# Altium schematic sheet Sheet2.SchDoc
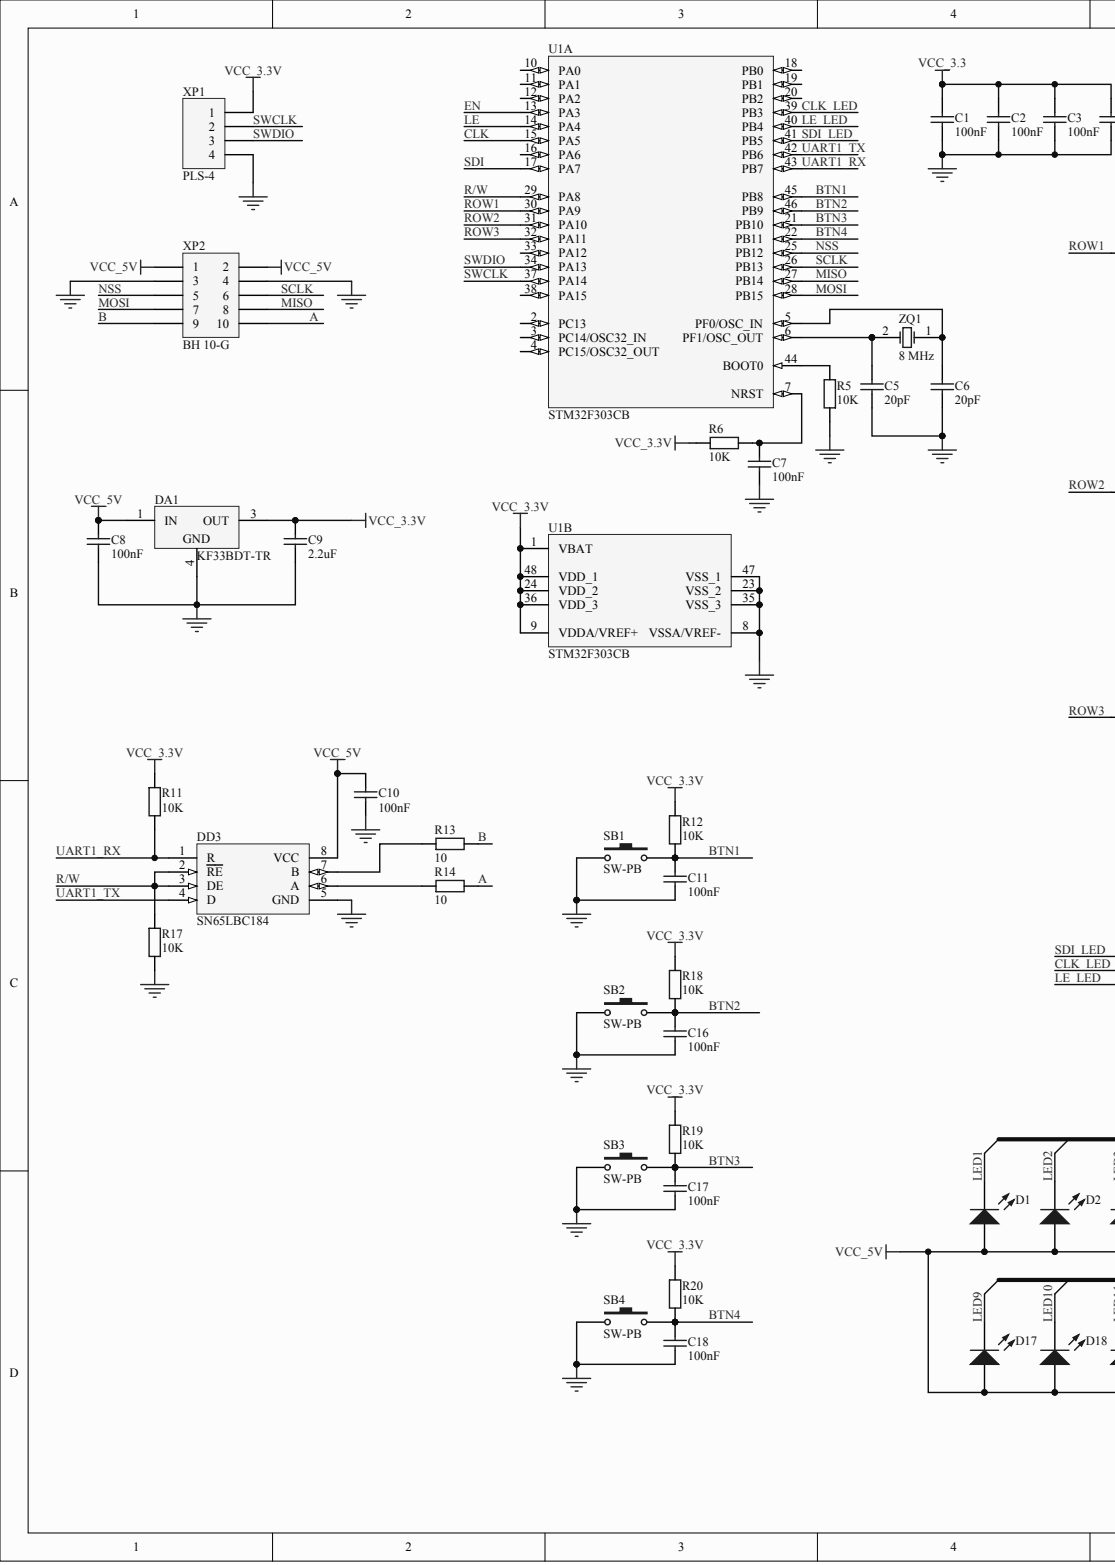
[diagram p0: Sheet2.SchDoc, left]
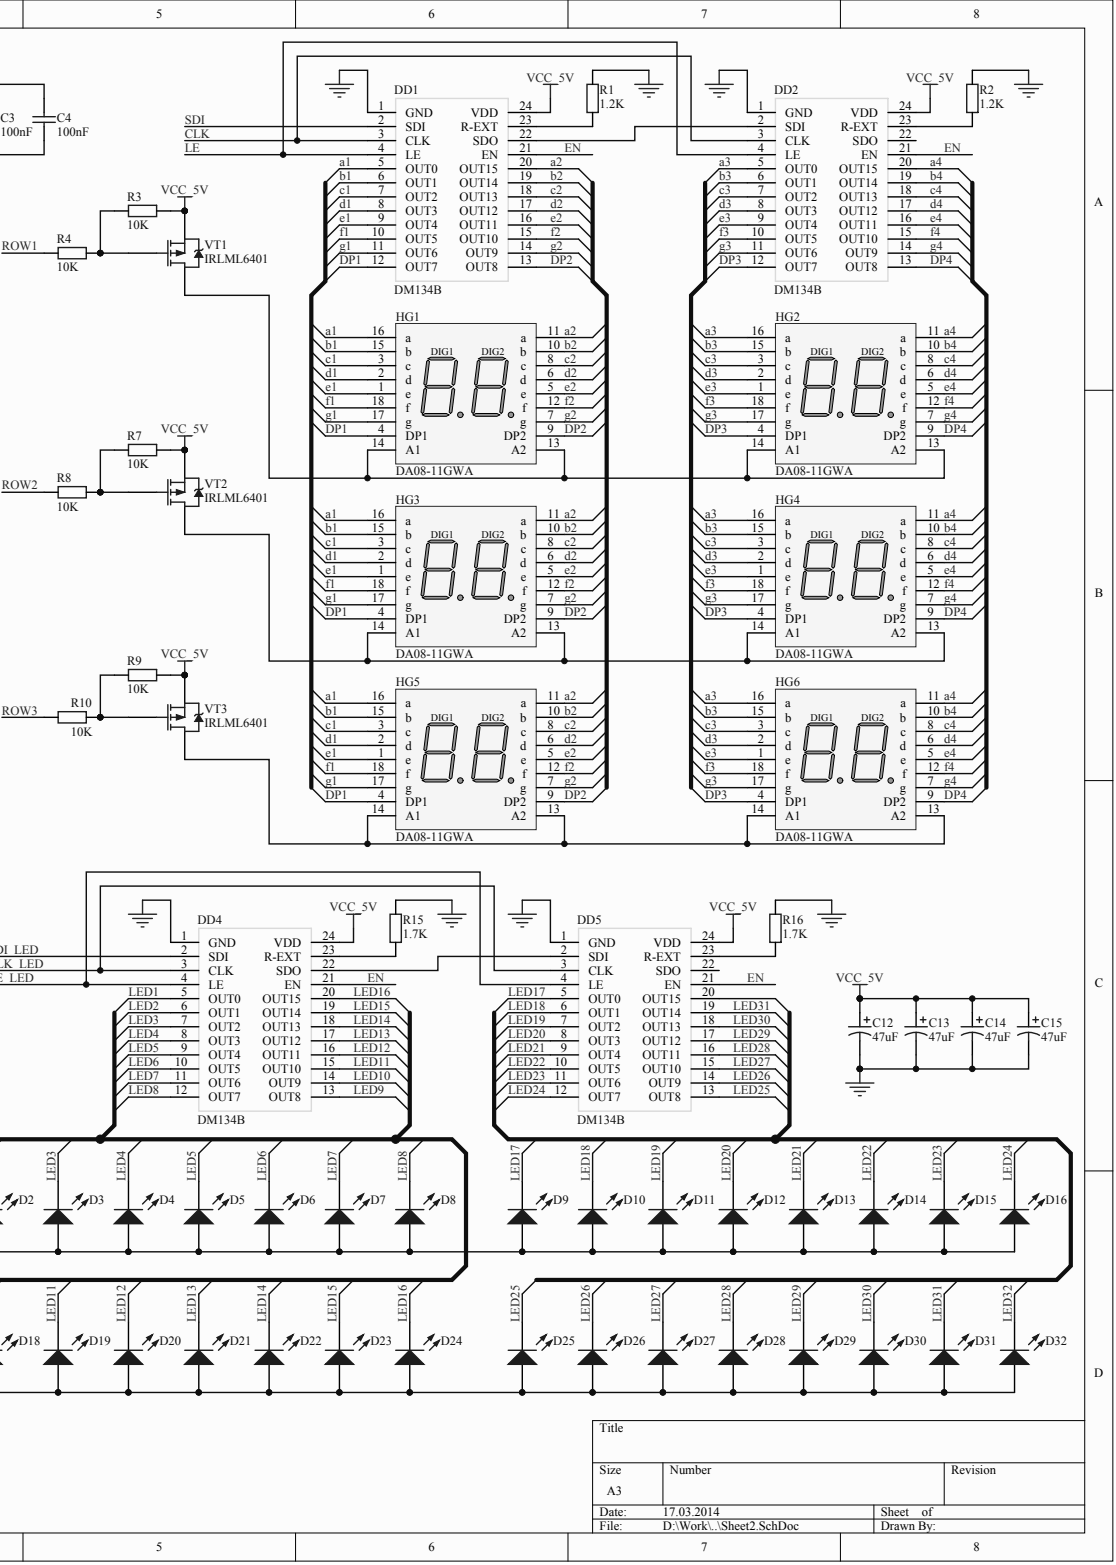
[diagram p1: Sheet2.SchDoc, right]

## Parts
C1  100nF
C2  100nF
C3  100nF
C4  100nF
C5  20pF
C6  20pF
C7  100nF
C8  100nF
C9  2.2uF
C10  100nF
C11  100nF
C12  47uF
C13  47uF
C14  47uF
C15  47uF
C16  100nF
C17  100nF
C18  100nF
D1  LED0  (value not drawn)
D2  LED0  (value not drawn)
D3  LED0  (value not drawn)
D4  LED0  (value not drawn)
D5  LED0  (value not drawn)
D6  LED0  (value not drawn)
D7  LED0  (value not drawn)
D8  LED0  (value not drawn)
D9  LED0  (value not drawn)
D10  LED0  (value not drawn)
D11  LED0  (value not drawn)
D12  LED0  (value not drawn)
D13  LED0  (value not drawn)
D14  LED0  (value not drawn)
D15  LED0  (value not drawn)
D16  LED0  (value not drawn)
D17  LED0  (value not drawn)
D18  LED0  (value not drawn)
D19  LED0  (value not drawn)
D20  LED0  (value not drawn)
D21  LED0  (value not drawn)
D22  LED0  (value not drawn)
D23  LED0  (value not drawn)
D24  LED0  (value not drawn)
D25  LED0  (value not drawn)
D26  LED0  (value not drawn)
D27  LED0  (value not drawn)
D28  LED0  (value not drawn)
D29  LED0  (value not drawn)
D30  LED0  (value not drawn)
D31  LED0  (value not drawn)
D32  LED0  (value not drawn)
DA1  KF33BDT-TR
DD1  DM134B
DD2  DM134B
DD3  SN65LBC184
DD4  DM134B
DD5  DM134B
HG1  DA08-11GWA
HG2  DA08-11GWA
HG3  DA08-11GWA
HG4  DA08-11GWA
HG5  DA08-11GWA
HG6  DA08-11GWA
R1  1.2K
R2  1.2K
R3  10K
R4  10K
R5  10K
R6  10K
R7  10K
R8  10K
R9  10K
R10  10K
R11  10K
R12  10K
R13  10
R14  10
R15  1.7K
R16  1.7K
R17  10K
R18  10K
R19  10K
R20  10K
SB1  SW-PB
SB2  SW-PB
SB3  SW-PB
SB4  SW-PB
U1  STM32F303CB  (parts A,B)
VT1  IRLML6401
VT2  IRLML6401
VT3  IRLML6401
XP1  PLS-4
XP2  BH 10-G
ZQ1  8 MHz

## Nets
VCC_3.3: C1.2 C2.2 C3.2 C4.2
VCC_3.3V: C9.2 DA1.3 R6.2 R11.2 R12.2 R18.2 R19.2 R20.2 U1.1(VBAT) U1.9(VDDA/VREF+) U1.24(VDD_2) U1.36(VDD_3) U1.48(VDD_1) XP1.1
VCC_5V: C8.2 C10.1 C12.1 C13.1 C14.1 C15.1 D1.1 D2.1 D3.1 D4.1 D5.1 D6.1 D7.1 D8.1 D9.1 D10.1 D11.1 D12.1 D13.1 D14.1 D15.1 D16.1 D17.1 D18.1 D19.1 D20.1 D21.1 D22.1 D23.1 D24.1 D25.1 D26.1 D27.1 D28.1 D29.1 D30.1 D31.1 D32.1 DA1.1 DD1.24(VDD) DD2.24(VDD) DD3.8(VCC) DD4.24(VDD) DD5.24(VDD) R3.2 R7.2 R9.2 VT1.2 VT2.2 VT3.2 XP2.1 XP2.2
: C1.1 C2.1 C3.1 C4.1 C5.1 C6.1 C7.1 C8.1 C9.1 C10.2 C11.1 C12.2 C13.2 C14.2 C15.2 C16.1 C17.1 C18.1 DA1.4 DD1.1(GND) DD2.1(GND) DD3.5(GND) DD4.1(GND) DD5.1(GND) R1.1 R2.1 R5.2 R15.1 R16.1 R17.1 SB1.2 SB2.2 SB3.2 SB4.2 U1.8(VSSA/VREF-) U1.23(VSS_2) U1.35(VSS_3) U1.47(VSS_1) XP1.4 XP2.3 XP2.4
A: R14.1 XP2.10
A1: DD1.5(OUT0) HG1.16(a) HG3.16(a) HG5.16(a)
A2: DD1.20(OUT15) HG1.11(a) HG3.11(a) HG5.11(a)
A3: DD2.5(OUT0) HG2.16(a) HG4.16(a) HG6.16(a)
A4: DD2.20(OUT15) HG2.11(a) HG4.11(a) HG6.11(a)
B: R13.1 XP2.9
B1: DD1.6(OUT1) HG1.15(b) HG3.15(b) HG5.15(b)
B2: DD1.19(OUT14) HG1.10(b) HG3.10(b) HG5.10(b)
B3: DD2.6(OUT1) HG2.15(b) HG4.15(b) HG6.15(b)
B4: DD2.19(OUT14) HG2.10(b) HG4.10(b) HG6.10(b)
BTN1: C11.2 R12.1 SB1.1 U1.45(PB8)
BTN2: C16.2 R18.1 SB2.1 U1.46(PB9)
BTN3: C17.2 R19.1 SB3.1 U1.21(PB10)
BTN4: C18.2 R20.1 SB4.1 U1.22(PB11)
C1: DD1.7(OUT2) HG1.3(c) HG3.3(c) HG5.3(c)
C2: DD1.18(OUT13) HG1.8(c) HG3.8(c) HG5.8(c)
C3: DD2.7(OUT2) HG2.3(c) HG4.3(c) HG6.3(c)
C4: DD2.18(OUT13) HG2.8(c) HG4.8(c) HG6.8(c)
CLK: DD1.3(CLK) DD2.3(CLK) U1.15(PA5)
CLK_LED: DD4.3(CLK) DD5.3(CLK) U1.39(PB3)
D1: DD1.8(OUT3) HG1.2(d) HG3.2(d) HG5.2(d)
D2: DD1.17(OUT12) HG1.6(d) HG3.6(d) HG5.6(d)
D3: DD2.8(OUT3) HG2.2(d) HG4.2(d) HG6.2(d)
D4: DD2.17(OUT12) HG2.6(d) HG4.6(d) HG6.6(d)
DP1: DD1.12(OUT7) HG1.4(DP1) HG3.4(DP1) HG5.4(DP1)
DP2: DD1.13(OUT8) HG1.9(DP2) HG3.9(DP2) HG5.9(DP2)
DP3: DD2.12(OUT7) HG2.4(DP1) HG4.4(DP1) HG6.4(DP1)
DP4: DD2.13(OUT8) HG2.9(DP2) HG4.9(DP2) HG6.9(DP2)
E1: DD1.9(OUT4) HG1.1(e) HG3.1(e) HG5.1(e)
E2: DD1.16(OUT11) HG1.5(e) HG3.5(e) HG5.5(e)
E3: DD2.9(OUT4) HG2.1(e) HG4.1(e) HG6.1(e)
E4: DD2.16(OUT11) HG2.5(e) HG4.5(e) HG6.5(e)
EN: DD1.21(EN) DD2.21(EN) DD4.21(EN) DD5.21(EN) U1.13(PA3)
F1: DD1.10(OUT5) HG1.18(f) HG3.18(f) HG5.18(f)
F2: DD1.15(OUT10) HG1.12(f) HG3.12(f) HG5.12(f)
F3: DD2.10(OUT5) HG2.18(f) HG4.18(f) HG6.18(f)
F4: DD2.15(OUT10) HG2.12(f) HG4.12(f) HG6.12(f)
G1: DD1.11(OUT6) HG1.17(g) HG3.17(g) HG5.17(g)
G2: DD1.14(OUT9) HG1.7(g) HG3.7(g) HG5.7(g)
G3: DD2.11(OUT6) HG2.17(g) HG4.17(g) HG6.17(g)
G4: DD2.14(OUT9) HG2.7(g) HG4.7(g) HG6.7(g)
LE: DD1.4(LE) DD2.4(LE) U1.14(PA4)
LE_LED: DD4.4(LE) DD5.4(LE) U1.40(PB4)
LED1: D1.2 DD4.5(OUT0)
LED2: D2.2 DD4.6(OUT1)
LED3: D3.2 DD4.7(OUT2)
LED4: D4.2 DD4.8(OUT3)
LED5: D5.2 DD4.9(OUT4)
LED6: D6.2 DD4.10(OUT5)
LED7: D7.2 DD4.11(OUT6)
LED8: D8.2 DD4.12(OUT7)
LED9: D17.2 DD4.13(OUT8)
LED10: D18.2 DD4.14(OUT9)
LED11: D19.2 DD4.15(OUT10)
LED12: D20.2 DD4.16(OUT11)
LED13: D21.2 DD4.17(OUT12)
LED14: D22.2 DD4.18(OUT13)
LED15: D23.2 DD4.19(OUT14)
LED16: D24.2 DD4.20(OUT15)
LED17: D9.2 DD5.5(OUT0)
LED18: D10.2 DD5.6(OUT1)
LED19: D11.2 DD5.7(OUT2)
LED20: D12.2 DD5.8(OUT3)
LED21: D13.2 DD5.9(OUT4)
LED22: D14.2 DD5.10(OUT5)
LED23: D15.2 DD5.11(OUT6)
LED24: D16.2 DD5.12(OUT7)
LED25: D25.2 DD5.13(OUT8)
LED26: D26.2 DD5.14(OUT9)
LED27: D27.2 DD5.15(OUT10)
LED28: D28.2 DD5.16(OUT11)
LED29: D29.2 DD5.17(OUT12)
LED30: D30.2 DD5.18(OUT13)
LED31: D31.2 DD5.19(OUT14)
LED32: D32.2
MISO: U1.27(PB14) XP2.8
MOSI: U1.28(PB15) XP2.7
NSS: U1.25(PB12) XP2.5
R/W: DD3.2(RE) DD3.3(DE) R17.2 U1.29(PA8)
ROW1: R4.1 U1.30(PA9)
ROW2: R8.1 U1.31(PA10)
ROW3: R10.1 U1.32(PA11)
SCLK: U1.26(PB13) XP2.6
SDI: DD1.2(SDI) U1.17(PA7)
SDI_LED: DD4.2(SDI) U1.41(PB5)
SWCLK: U1.37(PA14) XP1.2
SWDIO: U1.34(PA13) XP1.3
UART1_RX: DD3.1(R) R11.1 U1.43(PB7)
UART1_TX: DD3.4(D) U1.42(PB6)
NetC5_2: C5.2 U1.6(PF1/OSC_OUT) ZQ1.2
NetC6_2: C6.2 U1.5(PF0/OSC_IN) ZQ1.1
NetC7_2: C7.2 R6.1 U1.7(NRST)
NetDD1_22: DD1.22(SDO) DD2.2(SDI)
NetDD1_23: DD1.23(R-EXT) R1.2
NetDD2_23: DD2.23(R-EXT) R2.2
NetDD3_6: DD3.6(A) R14.2
NetDD3_7: DD3.7(B) R13.2
NetDD4_22: DD4.22(SDO) DD5.2(SDI)
NetDD4_23: DD4.23(R-EXT) R15.2
NetDD5_23: DD5.23(R-EXT) R16.2
NetHG1_13: HG1.13(A2) HG1.14(A1) HG2.13(A2) HG2.14(A1) VT1.3
NetHG3_13: HG3.13(A2) HG3.14(A1) HG4.13(A2) HG4.14(A1) VT2.3
NetHG5_13: HG5.13(A2) HG5.14(A1) HG6.13(A2) HG6.14(A1) VT3.3
NetR3_1: R3.1 R4.2 VT1.1
NetR5_1: R5.1 U1.44(BOOT0)
NetR7_1: R7.1 R8.2 VT2.1
NetR9_1: R9.1 R10.2 VT3.1
N00079:
N00080:
N00081:
N00082:
N00083:
N00084:

Not connected: DD2 22(SDO); DD5 20(OUT15) 22(SDO); U1 2(PC13) 3(PC14/OSC32_IN) 4(PC15/OSC32_OUT) 10(PA0) 11(PA1) 12(PA2) 16(PA6) 18(PB0) 19(PB1) 20(PB2) 33(PA12) 38(PA15)

## Library data of the parts
C1-C4 C7 C8 C10 C11 C16-C18 = (no symbol name): Value: 0.1uF; Published: 8-Jun-2000; LatestRevisionDate: 17-Jul-2002; LatestRevisionNote: Re-released for DXP Platform.; PackageReference: RAD-0.3; PackageDescription: Radial Cap, Thru-Hole; 2 Leads; 0.3 in Pin Spacing; Publisher: Altium Limited
C12-C15 = (no symbol name): Note: See Data Sheet for full range of components; Published: 19-Mar-2004; DatasheetDocument: 05-Jul-2002; PackageReference: 49BC-P; ComponentLink1Description: Manufacturer Link; ComponentLink1URL: http://www.vishay.com/; Value: 47uF; Publisher: Altium Limited
C5 C6 C9 D1-D32 DA1 DD1-DD5 HG1-HG6 R1-R20 SB1-SB4 U1 VT1-VT3 XP1 XP2 ZQ1 = (no symbol name)
  C5 C6: Published: 8-Jun-2000; LatestRevisionDate: 17-Jul-2002; LatestRevisionNote: Re-released for DXP Platform.; PackageReference: RAD-0.3; PackageDescription: Radial Cap, Thru-Hole; 2 Leads; 0.3 in Pin Spacing; Value: 20pF
  C9: Published: 8-Jun-2000; LatestRevisionDate: 17-Jul-2002; LatestRevisionNote: Re-released for DXP Platform.; PackageReference: RAD-0.3; PackageDescription: Radial Cap, Thru-Hole; 2 Leads; 0.3 in Pin Spacing; Value: 2.2uF
  D1-D32: Published: 8-Jun-2000; LatestRevisionDate: 08-Jul-2005; LatestRevisionNote: Mech Layer 1 removed.; PackageReference: LED-0; PackageDescription: LED; 2 Leads
  DA1: DatasheetVersion: Feb-2008; PackageVersion: 2011; PackageReference: TO-252; PackageDescription: 3-Pin Transistor Outline Package 2.28 mm Pitch, 9.7 mm Lead Span (DPAK); PartNumber: KF33BDT-TR; ComponentLink1Description: Manufacturer URL; ComponentLink1URL: http://www.st.com; ComponentLink2Description: Datasheet; ComponentLink2URL: http://www.st.com/internet/com/TECHNICAL_RESOURCES/TECHNICAL_LITERATURE/DATASHEET/CD00000970.pdf
  DD1 DD2 DD4 DD5: Type: LED Driver
  SB1-SB4: Published: 24-Mar-1999; LatestRevisionDate: 17-Jul-2002; LatestRevisionNote: Re-released for DXP Platform.; PackageReference: SPST-2; PackageDescription: Switch; 2 Leads
  U1: DatasheetVersion: rev6.0, Apr-2011; PackageVersion: rev13, Apr-2011; PackageReference: LQFP48; PackageDescription: 48-Pin Low-Profile Quad Flat Pack, 7 x 7 mm Body, 0.5 mm Pitch (LQFP48); ComponentLink1Description: Manufacturer URL; ComponentLink1URL: http://www.st.com/; ComponentLink2Description: STM32F303 Datasheet; ComponentLink2URL: http://www.st.com/st-web-ui/static/active/en/resource/technical/document/datasheet/DM00058181.pdf; ComponentLink3Description: STM32 Family Web Page; ComponentLink3URL: www.st.com/stm32
  VT1-VT3: Published: 17-Sep-2003; DatasheetVersion: 2-Jul-2001; PackageVersion: Jul-2001; PackageReference: Micro3; PackageDescription: 3-Pin SOT-23 Package 0.95 mm Pitch, 2.3 mm Lead Span; ComponentLink1Description: Manufacturer Link; ComponentLink1URL: http://www.irf.com/; ComponentLink2Description: Datasheet; ComponentLink2URL: http://www.irf.com/product-info/datasheets/data/IRLML6401.pdf
  XP1 XP2: LatestRevisionDate: 17-Jul-2002; LatestRevisionNote: Re-released for DXP Platform.
  ZQ1: Published: 8-Jun-2000; LatestRevisionDate: 17-Jul-2002; LatestRevisionNote: Re-released for DXP Platform.; PackageReference: R38; PackageDescription: Crystal, Thru-Hole; 2 Leads; Body 3.1 x 8.2 mm (Dia.xH)
  C5 C6 C9 D1-D32 SB1-SB4 VT1-VT3 XP1 XP2 ZQ1: Publisher: Altium Limited
